# Altium schematic sheet leds.SchDoc(leds)
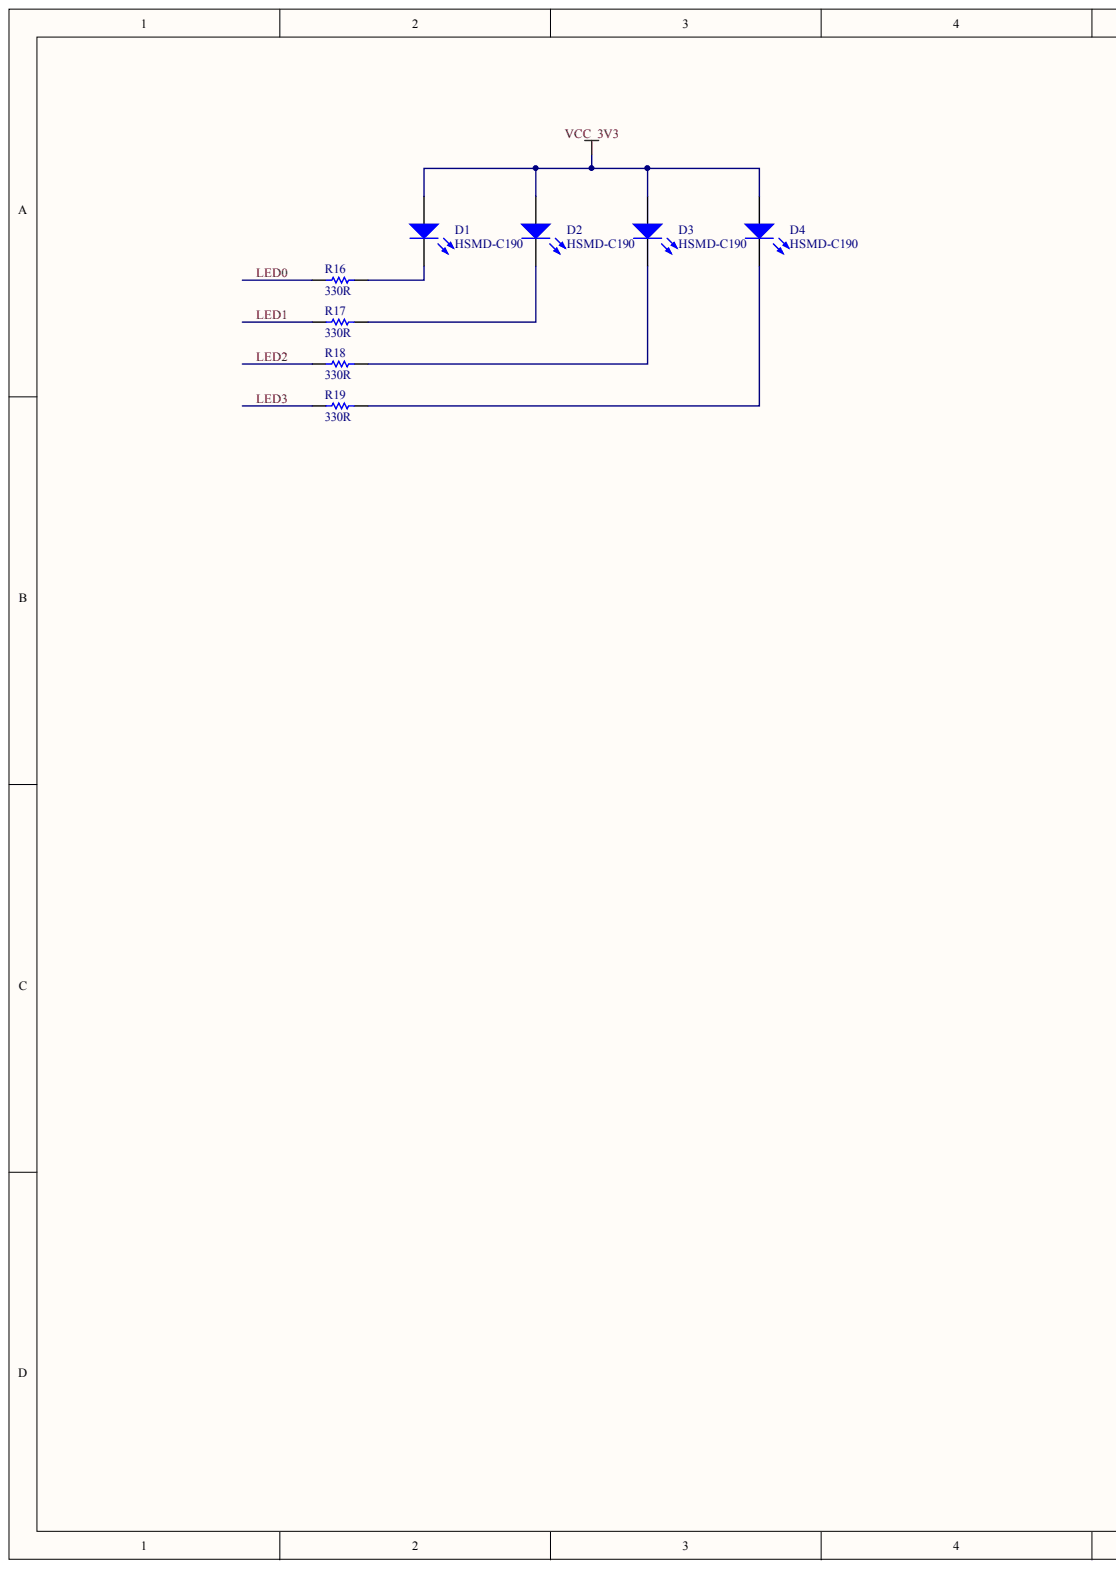
[diagram p0: leds.SchDoc, left]
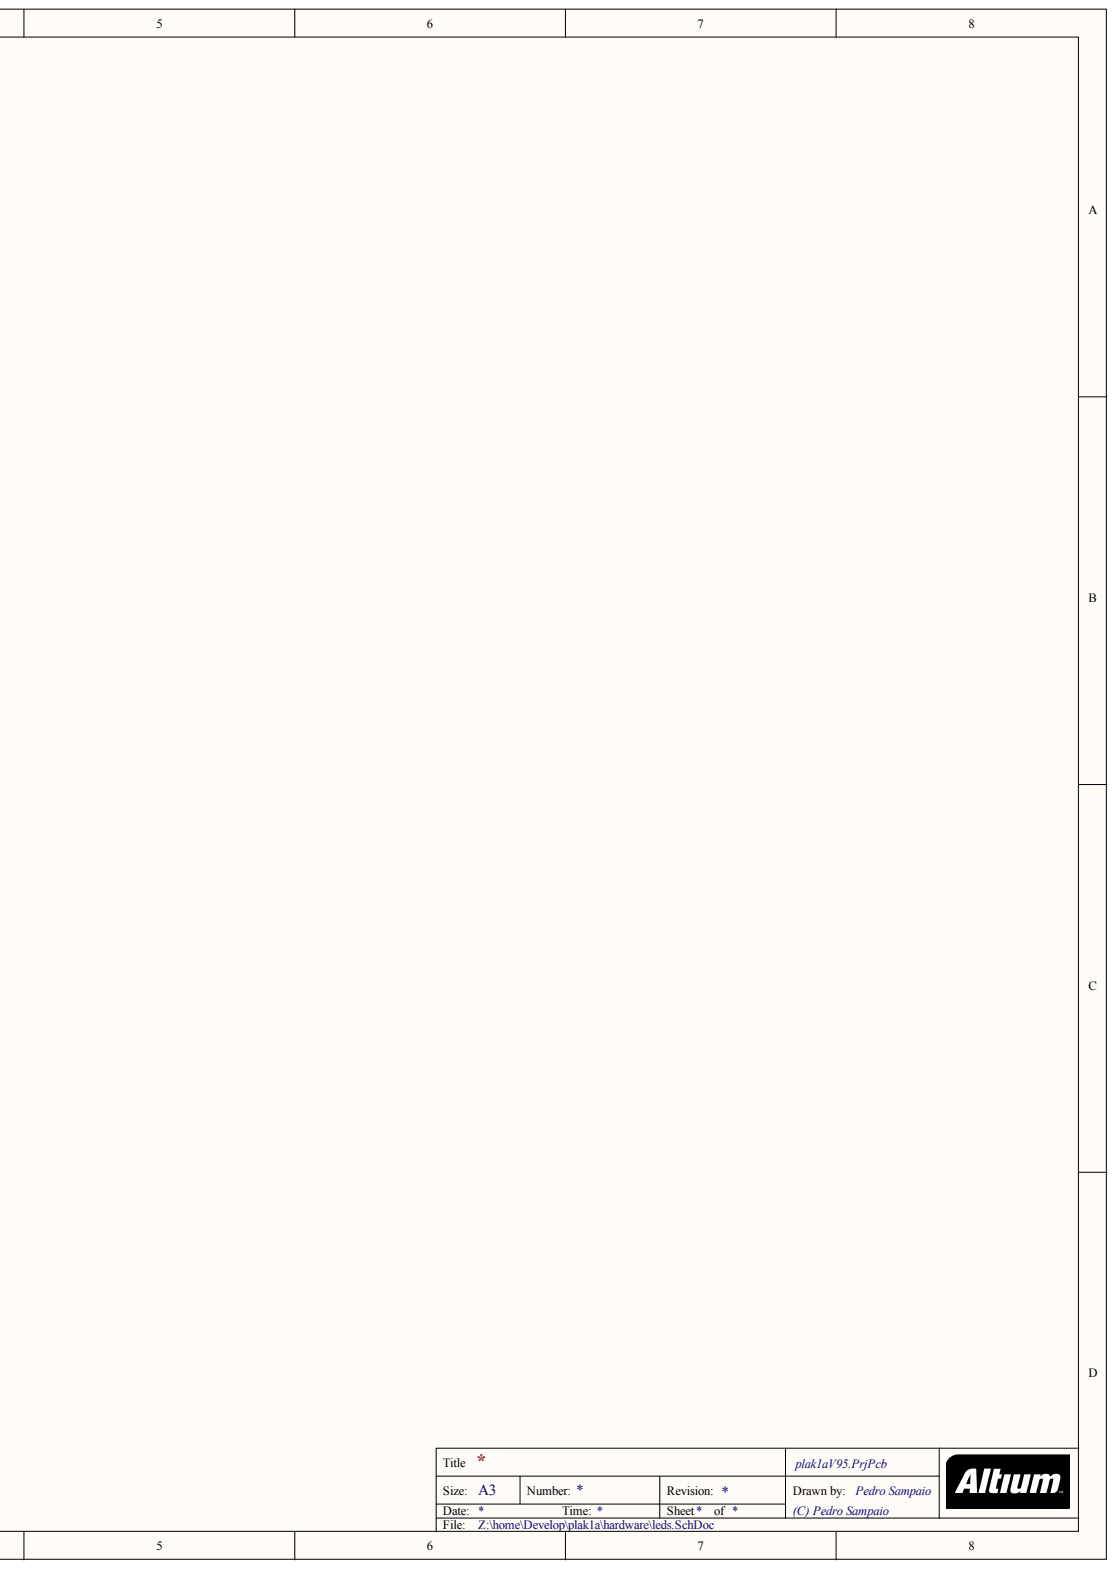
[diagram p1: leds.SchDoc, right]

## Parts
D1  HSMD-C190  [1.6X0.8X0.8]
D2  HSMD-C190  [1.6X0.8X0.8]
D3  HSMD-C190  [1.6X0.8X0.8]
D4  HSMD-C190  [1.6X0.8X0.8]
R16  value 330R  [3216[1206]]  (hidden comment: Res Semi)
R17  value 330R  [3216[1206]]  (hidden comment: Res Semi)
R18  value 330R  [3216[1206]]  (hidden comment: Res Semi)
R19  value 330R  [3216[1206]]  (hidden comment: Res Semi)

## Nets
VCC_3V3: D1.1 D2.1 D3.1 D4.1
LED0: R16.2
LED1: R17.2
LED2: R18.2
LED3: R19.2
NetD1_2: D1.2 R16.1
NetD2_2: D2.2 R17.1
NetD3_2: D3.2 R18.1
NetD4_2: D4.2 R19.1

## Library data of the parts
D1-D4 = HSMD-C190: Surface Mount Chip LED, Orange; LuminousIntensity: 2.5mcd (Minimum).; PCB_Layout: Complies with manufacturer's recommendation.; Component Kind: Standard; ComponentLink1Description: Manufacturer Link; ComponentLink1URL: http://www.agilent.com/; ComponentLink2Description: Datasheet; ComponentLink2URL: http://cp.literature.agilent.com/litweb/pdf/5988-6271EN.pdf; DatasheetDocument: 30-Apr-2002; LED_Colour: Orange; Library Name: Agilent Optoelectronic LED.IntLib; PackageDocument: 11-Mar-2002; PackageReference: 1.6X0.8X0.8; Published: 11-Dec-2002; Signal Integrity: LED; Simulation: Agl_HSMDC110; Publisher: Altium Limited
R16-R19 = Res Semi: Semiconductor Resistor; Component Kind: Standard; Farnell: 1527413; LatestRevisionDate: 17-Jul-2002; LatestRevisionNote: Re-released for DXP Platform.; Library Name: Miscellaneous Devices.IntLib; PackageReference: AXIAL-0.5; Published: 8-Jun-2000; Signal Integrity: Res Semi; Simulation: RES; Publisher: Altium Limited
